# Altium schematic sheet Regenerative_Braking_Protection.SchDoc
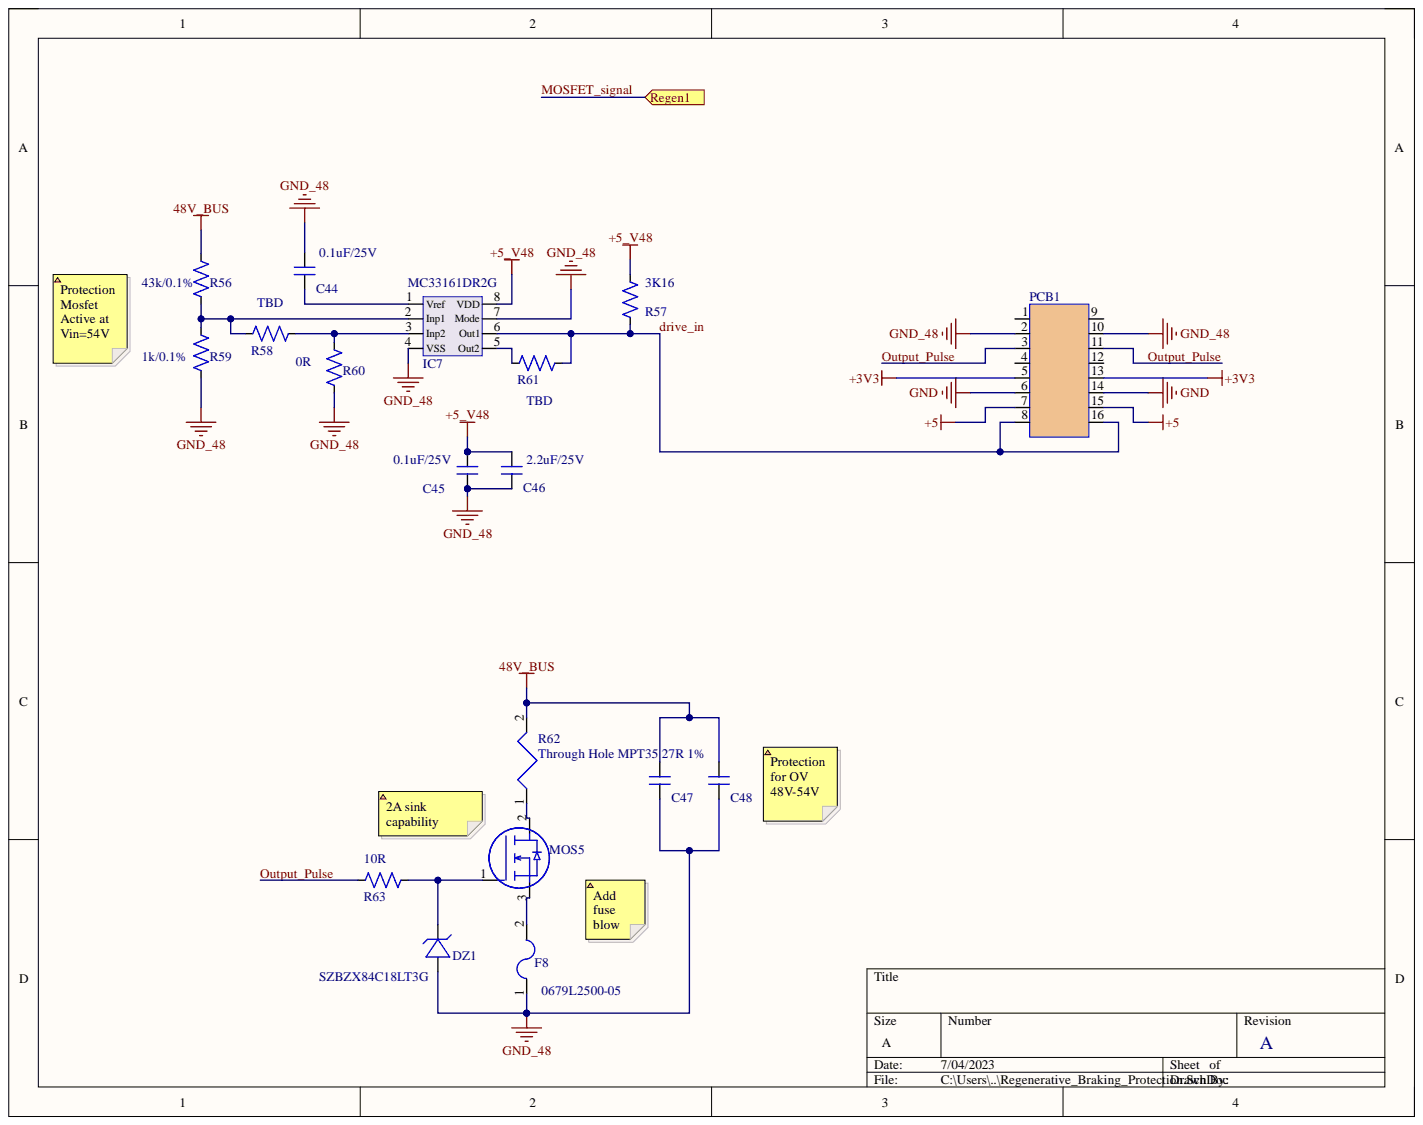
[diagram p0: Regenerative_Braking_Protection.SchDoc]

## Parts
C44  0.1uF/25V  [C0402]
C45  0.1uF/25V  [C0402]
C46  2.2uF/25V  [C0402]
C47  10uF/100V  [C1210]  (value not drawn)
C48  10uF/100V  [C1210]  (value not drawn)
DZ1  SZBZX84C18LT3G  [SOT-23-3]
F8  0679L2500-05  [F2410]
IC7  MC33161DR2G  [SOIC-8]
MOS5  CSD19535KCS  [TO-220-AB]  (value not drawn)
PCB1  Comment  [ADUM3221ARZ_Breakout]  (value not drawn)
R56  43k/0.1%  [R0805]
R57  3K16  [R0603]
R58  TBD  [R0402]
R59  1k/0.1%  [R0805]
R60  0R  [R0402]
R61  TBD  [R0402]
R62  Through Hole MPT35 27R 1%  [Through Hole MPT35 27R 1%]
R63  10R  [R0402]

## Ports
Regen1

## Nets
48V_BUS: C47.1 C48.1 R56.2 R62.2
+3V3: PCB1.5 PCB1.13
+5: PCB1.7 PCB1.15
+5_V48: C45.2 C46.1 IC7.8(VDD) R57.2
GND: PCB1.6 PCB1.14
GND_48: C44.2 C45.1 C46.2 C47.2 C48.2 DZ1.2 F8.1 IC7.4(VSS) IC7.7(Mode) PCB1.2 PCB1.10 R59.1 R60.1
drive_in: IC7.6(Out1) PCB1.8 PCB1.16 R57.1 R61.1
Output_Pulse: PCB1.3 PCB1.11 R63.1
NetC44_1: C44.1 IC7.1(Vref)
NetDZ1_1: DZ1.1 MOS5.1 R63.2
NetF8_2: F8.2 MOS5.3
NetIC7_2: IC7.2(Inp1) R56.1 R58.2 R59.2
NetIC7_3: IC7.3(Inp2) R58.1 R60.2
NetIC7_5: IC7.5(Out2) R61.2
NetMOS5_2: MOS5.2 R62.1
MOSFET_signal: ; port Regen1

Not connected: PCB1 1 4 9 12

## Library data of the parts
C44 C45 = C0402C104K3RACTU: Multilayer Ceramic 25V 0.1uF X7R 0402 10%; Manufacturer: Yageo; Database Table Name: components; Case/Package: 0402; Component Kind: Standard; Library Name: Resistors-Capacitors.DbLib; Part Number: C0402C104K3RACTU; Supplier 1: Mouser; Supplier Part Number 1: 80-C0402C104K3R
C46 = GRM155C81E225ME11D: Ceramic 2.2UF 25V 20% 0402; Database Table Name: components; Case/Package: 0402; Component Kind: Standard; Library Name: Resistors-Capacitors.DbLib; Part Number: GRM155C81E225ME11D
C47 C48 = GRM32EC72A106KE05L: Multilayer Ceramic Capacitors MLCC - SMD/SMT; Manufacturer: Murata; Capacitance: 10uF; Database Table Name: components; Tolerance: 10%; Voltage Rating: 100V; Case Code (Imperial): 1210; Case Code (Metric): 3225; Case/Package: 1210; Category: Ceramic Capacitors; Component Kind: Standard; ComponentLink1Description: http://search.murata.co.jp/Ceramy/image/img/A; ComponentLink1URL: http://search.murata.co.jp/Ceramy/image/img/A; Library Name: Resistors-Capacitors.DbLib; Manufacturer Part Number: GRM32EC72A106KE05L; Max Operating Temperature: 125°C; Min Operating Temperature: -55°C; Mount: Surface Mount; Packaging: Tape and Reel; Part Number: GRM32EC72A106KE05L; Pricing 1: 1=1,10000, 10=0,75300, 50=0,75300, 100=0,6510; RoHS: Yes; RoHS Compliant: Yes; Stock 1: 270379; Supplier 1: Mouser; Supplier Part Number 1: 81-GRM32EC72A106KE05
DZ1 = SZBZX84C18LT3G: SZBZX84C18L Series 18 V 225 mW 10 mA SMT Zene; Manufacturer: ON Semiconductor; Database Table Name: components; Element Configuration: Single; Forward Voltage: 900mV; Impedance: 45R; Max Power Dissipation: 225mW; Max Reverse Current: 50nA; Tolerance: 6%; Working Voltage: 18V; Case/Package: SOT-23-3; Category: Zener Diodes; Component Kind: Standard; Lead Free: Lead Free; Library Name: Diodes.DbLib; Manufacturer Part Number: SZBZX84C18LT3G; Max Operating Temperature: 150°C; Max Reverse Leakage Current: 50nA; Min Operating Temperature: -55°C; Number of Pins: 3; Package Quantity: 10000; Packaging: Tape and Reel; Part Number: SZBZX84C18LT3G; Power Dissipation: 225mW; Pricing 1: 1=0,18000, 10=0,16000, 50=0,16000, 100=0,0880; Radiation Hardening: No; RoHS: Yes; Series: -; Stock 1: 14240; Supplier 1: Mouser; Supplier Part Number 1: 863-SZBZX84C18LT3G; Zener Voltage: 18V
F8 = 0679L2500-05: Fuse Brd Mnt 2.5A 125VAC/VDC; Manufacturer: Bel; Database Table Name: components; Case/Package: 2410; Category: Fuses; Component Kind: Standard; Library Name: Circuit_Protection.DbLib; Manufacturer Part Number: 0679L2500-05; Mount: Surface Mount; Part Number: 0679L2500-05; Pricing 1: 1=0,33000, 10=0,31300, 50=0,31300, 100=0,2590; RoHS: Yes; Stock 1: 7135; Supplier 1: Mouser; Supplier Part Number 1: 530-0679L2500-05
IC7 = MC33161DR2G: Supervisor Open Drain or Open Collector 2 Cha; Manufacturer: ON Semiconductor; Database Table Name: components; Case/Package: SOIC; Category: Voltage Supervisors; Component Kind: Standard; Library Name: ICs-smd.DbLib; Manufacturer Part Number: MC33161DR2G; Max Operating Temperature: 105°C; Min Operating Temperature: -40°C; Mount: Surface Mount; Number of Pins: 8; Package Quantity: 2500; Packaging: Tape and Reel; Part Number: MC33161DR2G; Pricing 1: 1=1,38000, 10=1,27000, 50=1,16000, 100=0,9850; RoHS: Yes; Stock 1: 47063; Supplier 1: Mouser; Supplier Part Number 1: 863-MC33161DR2G
MOS5 = CSD19535KCS: 100-V, N channel NexFET™ power MOSFET, single; Manufacturer: Texas Instruments; Database Table Name: components; Case/Package: TO-220; Category: MOSFETs; Component Kind: Standard; Library Name: Switches.DbLib; Manufacturer Part Number: CSD19535KCS; Mount: Through Hole; Part Number: CSD19535KCS; Pricing 1: 1=3,61000, 10=3,24000, 50=3,24000, 100=2,6500; RoHS: Yes; Stock 1: 33; Supplier 1: Mouser; Supplier Part Number 1: 595-CSD19535KCS
PCB1 = ADUM3221ARZ_Breakout: Description; Component Kind: Standard; Library Name: Custom_PCBAs.SchLib
R56 = RT0805BRD0743KL: Res Thin Film 0805 43K Ohm 0.1% 1/8W ±25ppm/°; Manufacturer: Yageo; Database Table Name: components; Max Power Dissipation: 125mW; Resistance: 43kR; Temperature Coefficient: 25ppm/°C; Voltage Rating: 150V; Case Code (Imperial): 0805; Case Code (Metric): 2012; Case/Package: 0805; Category: Chip SMD Resistors; Component Kind: Standard; ComponentLink1Description: https://datasheet.ciiva.com/2585/pyu-rt-1-to-; ComponentLink1URL: https://datasheet.ciiva.com/2585/pyu-rt-1-to-; Features: Moisture Resistant; Height: 0.024inch; Lead Free: Lead Free; Length: 0.079inch; Library Name: Resistors-Capacitors.DbLib; Manufacturer Part Number: RT0805BRD0743KL; Max Operating Temperature: 155°C; Min Operating Temperature: -55°C; Number of Terminations: 2; Package Quantity: 5000; Packaging: Tape and Reel; Part Number: RT0805BRD0743KL; Power Rating: 125mW; Pricing 1: 1=0,39000, 10=0,27800, 50=0,27800, 100=0,1120; Resistor Type: Thin Film; RoHS: Yes; RoHS Compliant: Yes; Series: RT; Size Code: 0805; Stock 1: 2727; Supplier 1: Mouser; Supplier Part Number 1: 603-RT0805BRD0743KL; Termination: SMD/SMT; Tolerance: 0.1%; Voltage Rating (DC): 150V; Weight: 0.000194oz; Width: 0.049inch
R57 = MCT06030C3161FP500: Res Thin Film 0603 3.16K Ohm 1% 1/10W ±50ppm/; Manufacturer: Vishay BCcomponents; Database Table Name: components; Max Power Dissipation: 125mW; Power Rating: 100mW; Resistance: 3.16kR; Temperature Coefficient: 50ppm/°C; Voltage Rating: 75V; Case Code (Imperial): 0603; Case Code (Metric): 1608; Case/Package: 0603; Category: Chip SMD Resistors; Component Kind: Standard; ComponentLink1Description: https://datasheet.ciiva.com/9112/mc-pro-91126; ComponentLink1URL: https://datasheet.ciiva.com/9112/mc-pro-91126; Construction: Rectangular; Contact Plating: Tin; Depth: 0.85mm; Features: Anti-Sulfur; Lead Free: Lead Free; Length: 1.55mm; Library Name: Resistors-Capacitors.DbLib; Manufacturer Part Number: MCT06030C3161FP500; Max Operating Temperature: 125°C; Military Standard: Not; Min Operating Temperature: -55°C; Mount: Surface Mount; Number of Terminations: 2; Packaging: Tape and Reel; Part Number: MCT06030C3161FP500; Pricing 1: 10=0,12800, 25=0,10200, 50=0,07500, 100=0,049; Radiation Hardening: No; REACH SVHC: Unknown; Resistance Tolerance: 1%; Resistor Type: Thin Film; RoHS: Yes; RoHS Compliant: Yes; Series: MCT - Professional; Stock 1: 202; Supplier 1: Mouser; Supplier Part Number 1: 594-MCT06030C3161FP5; Tolerance: 1%; Voltage Rating (DC): 75V; Width: 850um
R58 R61 = Res0402_TBD: Database Table Name: components; Component Kind: Standard; Library Name: Resistors-Capacitors.DbLib; Part Number: Res0402_TBD
R59 = ERA-6AEB102V: Res Thin Film 0805 1K Ohm 0.1% 0.125W(1/8W) +; Manufacturer: Panasonic; Database Table Name: components; Max Power Dissipation: 125mW; Resistance: 1kR; Temperature Coefficient: 25ppm/°C; Voltage Rating: 100V; Case Code (Imperial): 0805; Case Code (Metric): 2012; Case/Package: 0805; Category: Uncategorized; Component Kind: Standard; ComponentLink1Description: https://datasheet.ciiva.com/11811/0900766b80b; ComponentLink1URL: https://datasheet.ciiva.com/11811/0900766b80b; ComponentLink2Description: https://datasheet.ciiva.com/29184/453027-da-0; ComponentLink2URL: https://datasheet.ciiva.com/29184/453027-da-0; ComponentLink3Description: https://datasheet.ciiva.com/43455/485442-4345; ComponentLink3URL: https://datasheet.ciiva.com/43455/485442-4345; ComponentLink4Description: https://datasheet.ciiva.com/7942/485442-79424; ComponentLink4URL: https://datasheet.ciiva.com/7942/485442-79424; ComponentLink5Description: https://datasheet.ciiva.com/22783/485442-2278; ComponentLink5URL: https://datasheet.ciiva.com/22783/485442-2278; ComponentLink6Description: https://datasheet.ciiva.com/48536/era-eng-tds; ComponentLink6URL: https://datasheet.ciiva.com/48536/era-eng-tds; ComponentLink7Description: https://datasheet.ciiva.com/43457/485442-4345; ComponentLink7URL: https://datasheet.ciiva.com/43457/485442-4345; ComponentLink8Description: https://datasheet.ciiva.com/7942/485442-79424; ComponentLink8URL: https://datasheet.ciiva.com/7942/485442-79424; ComponentLink9Description: https://datasheet.ciiva.com/24025/aoa0000ce26; ComponentLink9URL: https://datasheet.ciiva.com/24025/aoa0000ce26; ComponentLink10Description: https://datasheet.ciiva.com/16782/70068849-16; ComponentLink10URL: https://datasheet.ciiva.com/16782/70068849-16; ComponentLink11Description: https://datasheet.ciiva.com/9198/aoa0000ce26-; ComponentLink11URL: https://datasheet.ciiva.com/9198/aoa0000ce26-; ComponentLink12Description: https://datasheet.ciiva.com/16201/aoa0000ce26; ComponentLink12URL: https://datasheet.ciiva.com/16201/aoa0000ce26; ComponentLink13Description: https://datasheet.ciiva.com/30057/54aoa0000ce; ComponentLink13URL: https://datasheet.ciiva.com/30057/54aoa0000ce; Construction: Rectangular; Contact Plating: Tin; Depth: 1.25mm; Height: 0.5mm; Length: 2mm; Library Name: Resistors-Capacitors.DbLib; Manufacturer Part Number: ERA-6AEB102V; Max Operating Temperature: 155°C; Military Standard: Not; Min Operating Temperature: -55°C; Mount: Surface Mount; Number of Pins: 2; Number of Terminations: 2; Package Quantity: 5000; Packaging: Tape and Reel; Part Number: ERA-6AEB102V; Power Rating: 125mW; Pricing 1: 1=0,20000, 10=0,16600, 50=0,16600, 100=0,1180; Radiation Hardening: No; Reach SVHC Compliant: Yes; Resistance Tolerance: 0.1%; Resistor Type: Thin Film; RoHS: Yes; RoHS Compliant: Yes; Series: ERA-6A; Stock 1: 285; Supplier 1: Mouser; Supplier Part Number 1: 667-ERA-6AEB102V; Technology: THIN FILM; Termination: SMD/SMT; Tolerance: 0.1%; Width: 1.25mm; Working Voltage: 100V
R60 = AC0402FR-070RL: AC Series 0402 0 Ohm JUMPER Thick Film Chip R; Manufacturer: Yageo; Database Table Name: components; Power Rating: 62.5mW; Temperature Coefficient: 200ppm/°C; Tolerance: 1%; Voltage Rating: 50V; Case Code (Imperial): 0402; Case Code (Metric): 1005; Case/Package: 0402; Category: Chip SMD Resistors; Component Kind: Standard; ComponentLink1Description: https://datasheet.ciiva.com/12656/pyu-ac-51-r; ComponentLink1URL: https://datasheet.ciiva.com/12656/pyu-ac-51-r; Library Name: Resistors-Capacitors.DbLib; Manufacturer Part Number: AC0402FR-070RL; Max Operating Temperature: 155°C; Min Operating Temperature: -55°C; Packaging: Tape and Reel; Part Number: AC0402FR-070RL; Pricing 1: 1=0,10000, 10=0,02000, 50=0,02000, 100=0,0090; Resistance: 0R; RoHS: Yes; Series: AC; Stock 1: 1298506; Supplier 1: Mouser; Supplier Part Number 1: 603-AC0402FR-070RL; Termination: SMD/SMT
R63 = RT0402FRE0710RL: Res Thin Film 0402 10 Ohm 1% 0.063W(1/16W) ±5; Manufacturer: Yageo; Database Table Name: components; Max Power Dissipation: 63mW; Power Rating: 62.5mW; Temperature Coefficient: 50ppm/°C; Voltage Rating: 50V; Case Code (Imperial): 0402; Case Code (Metric): 1005; Case/Package: 0402; Category: Chip SMD Resistors; Component Kind: Standard; ComponentLink1Description: https://datasheet.ciiva.com/12812/pyu-rt-1-to; ComponentLink1URL: https://datasheet.ciiva.com/12812/pyu-rt-1-to; Construction: Rectangular; Contact Plating: Tin,Matte,Lead; Depth: 0.5mm; Height: 0.3mm; Lead Free: Lead Free; Length: 1mm; Library Name: Resistors-Capacitors.DbLib; Manufacturer Part Number: RT0402FRE0710RL; Max Operating Temperature: 125°C; Military Standard: Not; Min Operating Temperature: -55°C; Number of Terminations: 2; Packaging: Tape and Reel; Part Number: RT0402FRE0710RL; Pricing 1: 1=0,10110, 10=0,08390, 25=0,08320, 100=0,0347; Radiation Hardening: No; Resistance: 10R; Resistor Type: Thin Film; RoHS: Yes; RoHS Compliant: Yes; Series: RT; Stock 1: 9607; Supplier 1: Mouser; Supplier Part Number 1: 603-RT0402FRE0710RL; Termination: SMD/SMT; Tolerance: 1%; Voltage Rating (DC): 50V; Weight: 2.3E-05oz; Width: 0.5mm
R62 = Through Hole MPT35 27R 1%: Component Kind: Standard; Library Name: Schlib1.SchLib
